# Altium schematic sheet SPI_SD.SchDoc
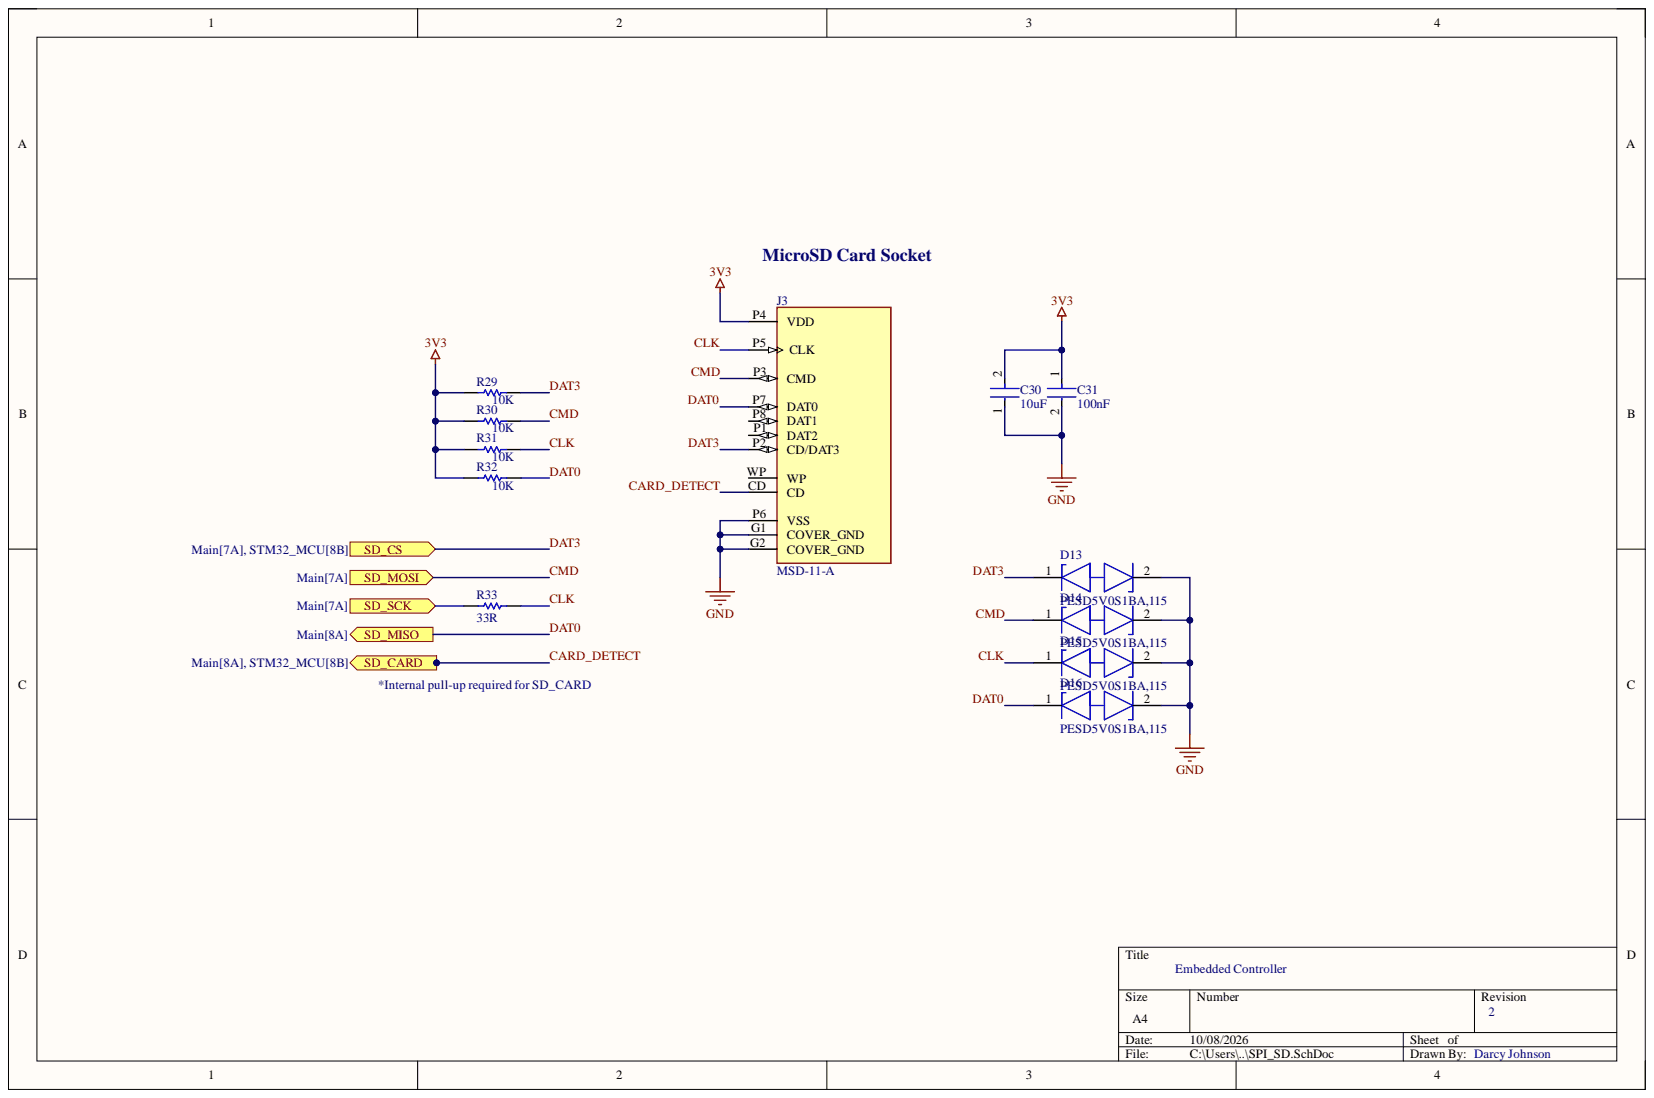
[diagram p0: SPI_SD.SchDoc]

## Parts
C30  10uF  [FP-C1608-080-0_1-MFG]
C31  100nF  [FP-CGA3E-0_3-0_1-IPC_A]
D13  PESD5V0S1BA,115  [FP-SOD323-CA-MFG]
D14  PESD5V0S1BA,115  [FP-SOD323-CA-MFG]
D15  PESD5V0S1BA,115  [FP-SOD323-CA-MFG]
D16  PESD5V0S1BA,115  [FP-SOD323-CA-MFG]
J3  MSD-11-A  [CUI_MSD-11-A]
R29  10K  [RESC1609X55X30LL15T15]
R30  10K  [RESC1609X55X30LL15T15]
R31  10K  [RESC1609X55X30LL15T15]
R32  10K  [RESC1609X55X30LL15T15]
R33  33R  [RESC1608X55X25LL10T15]

## Ports
SD_CARD, SD_CS, SD_MISO, SD_MOSI, SD_SCK

## Nets
3V3: C30.2 C31.1 J3.P4(VDD) R29.1 R30.1 R31.1 R32.1
GND: C30.1 C31.2 D13.2 D14.2 D15.2 D16.2 J3.G1(COVER_GND) J3.G2(COVER_GND) J3.P6(VSS)
CARD_DETECT: J3.CD ; port SD_CARD
CLK: D15.1 J3.P5(CLK) R31.2 R33.2
CMD: D14.1 J3.P3(CMD) R30.2 ; port SD_MOSI
DAT0: D16.1 J3.P7(DAT0) R32.2 ; port SD_MISO
DAT3: D13.1 J3.P2(CD/DAT3) R29.2 ; port SD_CS
SD_SCK: R33.1 ; port SD_SCK
NetJ3_P1: J3.P1(DAT2)
NetJ3_P8: J3.P8(DAT1)
NetJ3_WP: J3.WP

## Library data of the parts
C30 = CMP-2000-04979-3: Multilayer Ceramic Capacitors 10μF ±10% 10V X5R SMD 0603; Capacitance: 10uF; Case Code (Imperial): 0603; Case Code (Metric): 1608; Case/Package: 0603; Component Kind: Standard; Construction: Flat; Depth: 0.8mm; Dielectric: X5R; Dielectric Material: Ceramic; Height: 0.8mm; Lead Free: Lead Free; Length: 1.6mm; Library Name: Altium Content Vault; Max Operating Temperature: 85°C; Min Operating Temperature: -55°C; Mount: Surface Mount; Number of Pins: 2; Package Quantity: 1; Packaging: Tape and Reel; Radiation Hardening: No; REACH SVHC: No SVHC; Resistor Type: Ceramic; RoHS Compliant: Yes; Series: C; Termination: SMD/SMT; Thickness: 0.035inch; Tolerance: 10%; Voltage: 10V; Voltage Rating: 10V; Voltage Rating (DC): 10V; Weight: 7.1E-05oz; Width: 0.8mm
C31 = CMP-2000-07546-2: Cap Ceramic 100nF 50V X7R 10% Pad SMD 0603 +125°C Automotive T/R; Capacitance: 100nF; Case Code (Imperial): 0603; Case Code (Metric): 1608; Case/Package: 0603; Component Kind: Standard; Composition: Ceramic; Depth: 0.8mm; Dielectric: X7R; Height: 0.8mm; Lead Free: Lead Free; Length: 1.6mm; Library Name: Altium Content Vault; Max Operating Temperature: 125°C; Min Operating Temperature: -55°C; Mount: Surface Mount; Package Quantity: 1; Packaging: Tape and Reel; Radiation Hardening: No; Ratings: AEC-Q200; REACH SVHC: No SVHC; Termination: SMD/SMT; Thickness: 0.035inch; Tolerance: 10%; Voltage: 50V; Voltage Rating: 50V; Voltage Rating (DC): 50V; Weight: 7.1E-05oz; Width: 0.8mm
D13-D16 = CMP-14432-000001-1: TVS DIODE 5V 14V SOD323; Component Kind: Standard; Library Name: Altium Content Vault
J3 = MSD-11-A: Micro SD Card Connector, 8 Positions, Connector and Ejector, Push In, Auto Eject Out, Surface Mount, 1.85 mm Heaight Above Board, Gold Flash; MF: Same Sky; Check_prices: https://www.snapeda.com/parts/MSD-11-A/Same+Sky/view-part/?ref=eda; Component Kind: Standard; Library Name: MSD-11-A.IntLib; MP: MSD-11-A; Package: None; SnapEDA_Link: https://www.snapeda.com/parts/MSD-11-A/Same+Sky/view-part/?ref=snap
R29-R32 = CMP-1012-00623-3: Chip Resistor, 10 KOhm, +/- 1%, 0.1 W, -55 to 155 degC, 0603 (1608 Metric), RoHS, Tape and Reel; Manufacturer: Panasonic; Case\/Package: 0603; Max Power Dissipation: 100mW; Resistance: 10KOhm; Temperature Coefficient: 100ppm\/degreesC; Voltage Rating: 75V; Case Code (Imperial): 0603; Case Code (Metric): 1608; Component Kind: Standard; Composition: ThickFilm; Contact Plating: Tin; Datasheet URL: https://industrial.panasonic.com/cdbs/www-data/pdf/RDA0000/AOA0000C304.pdf; Datasheet Version: 02/2017; Depth: 0.8mm; Height: 0.45mm; Lead Free: LeadFree; Length: 1.6mm; Library Name: Altium Content Vault; Manufacturer URL: http://www.panasonic.com/; Max Operating Temperature: 155degC; Military Standard: Not; Min Operating Temperature: -55degC; Mount: SurfaceMount; Mounting Technology: SurfaceMount; Number of Pins: 2; Number of Terminations: 2; Package Description: 2-Pin Surface Mount Device, Body 1.6 x 0.85 mm; Package Quantity: 1; Package Reference: 603; Packaging: TapeandReel; Power Rating: 100mW; Radiation Hardening: No; REACH SVHC: NoSVHC; RoHSCompliant: true; Termination: SMD/SMT; Tolerance: 1%; Voltage Rating (DC): 75V; Width: 0.031inch
R33 = CMP-2000-06939-1: Chip Resistor, 33 Ohm, +/- 1%, 0.1 W, -55 to 155 degC, 0603 (1608 Metric), RoHS, Tape and Reel; Brand: Yageo; Power Rating: 100mW; Resistance: 33Ohms; Temperature Coefficient: 100PPM/C; Unit Weight: 0.000071oz; Voltage Rating: 75V; Case Code - in: 0603; Case Code - mm: 1608; Component Kind: Standard; Datasheet URL: http://www.yageo.com/documents/recent/PYu-RC_Group_51_RoHS_L_7.pdf; Datasheet Version: Rev. 7, 05/2017; Height: 0.45mm; Length: 1.6mm; Library Name: Altium Content Vault; Manufacturer: Yageo; Manufacturer URL: http://www.yageo.com/; Mounting Technology: SurfaceMount; Operating Temperature Range: -55Cto+155C; Package / Case: 0603(1608metric); Package Description: 2-Pin Surface Mount Device, Body 1.6 x 0.8 mm; Package Reference: 0603; Packaging: Reel; Product: ThickFilmResistorsGeneralPurpose; Product Category: ThickFilmResistors; RoHS: True; Series: RC; Termination Style: SMD/SMT; Tolerance: 1%; Type: GeneralPurposeChipResistorSurfaceMount; Width: 0.8mm
